# Altium schematic sheet Cryptography.SchDoc(Cryptography)
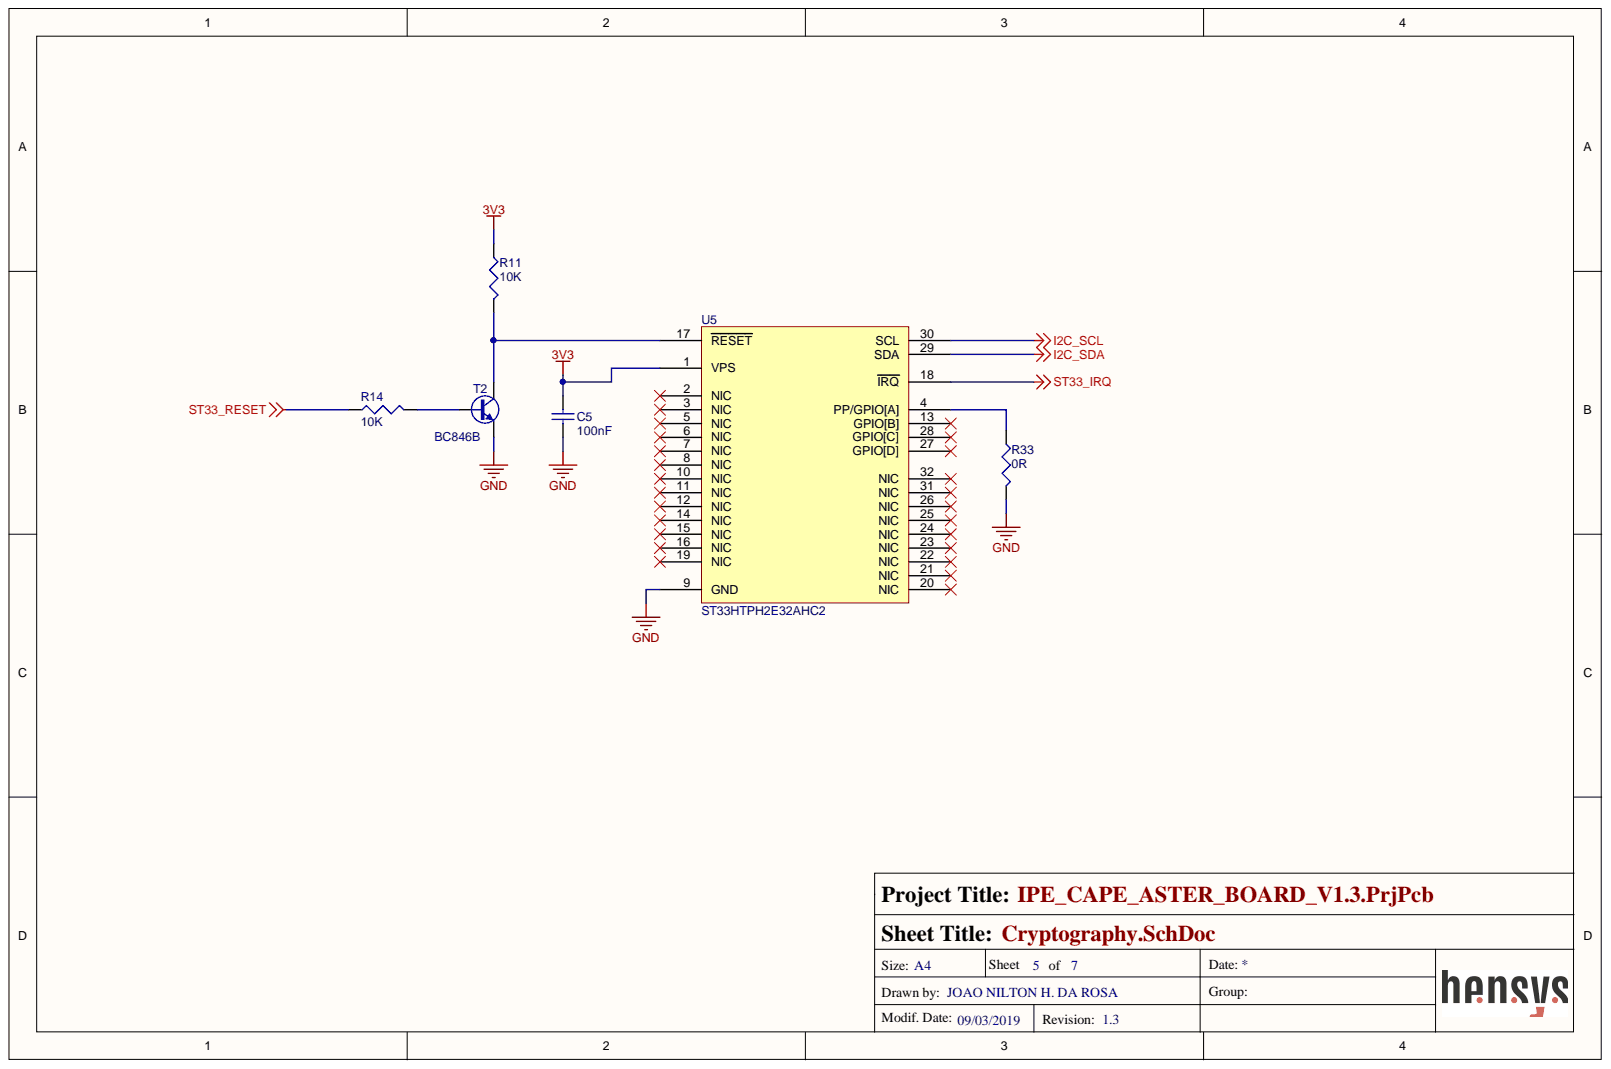
[diagram p0: Cryptography.SchDoc]

## Parts
C5  100nF  [0402 cap]
R11  10K  [0402 res]
R14  10K  [0402 res]
R33  0R  [0402 res]
T2  BC846B  [SOT95P230X110-3N]
U5  ST33HTPH2E32AHC2  [VFQFPN 32 5x5x1.0]

## Nets
3V3: C5.2 R11.1 U5.1(VPS)
GND: C5.1 R33.2 T2.2 U5.9(GND)
I2C_SCL: U5.30(SCL)
I2C_SDA: U5.29(SDA)
ST33_IRQ: U5.18(IRQ)
ST33_RESET: R14.1
NetR11_2: R11.2 T2.3 U5.17(RESET)
NetR14_2: R14.2 T2.1
NetR33_1: R33.1 U5.4(PP/GPIO[A])

Not connected: U5 2(NIC) 3(NIC) 5(NIC) 6(NIC) 7(NIC) 8(NIC) 10(NIC) 11(NIC) 12(NIC) 13(GPIO[B]) 14(NIC) 15(NIC) 16(NIC) 19(NIC) 20(NIC) 21(NIC) 22(NIC) 23(NIC) 24(NIC) 25(NIC) 26(NIC) 27(GPIO[D]) 28(GPIO[C]) 31(NIC) 32(NIC)

## Library data of the parts
C5 = Cap Ceram 0402: CAP CER 0.1UF 16V X7R 0402; Manufacturer Part Number: GCM155R71C104KA55D; Manufacturer: Murata Electronics North America; Tolerance: ±10%; Voltage - Rated: 16V; Thickness (Max): 0.022" (0.55mm); Temperature Coefficient: X7R; Supplier Part Number 1: 490-4759-1-ND; Supplier 1: Digi-Key; Stock 1: 918618; Size / Dimension: 0.039" L x 0.020" W (1.00mm x 0.50mm); RoHS: RoHS Compliant; Ratings: AEC-Q200; Pricing 1: 1=0.1, 10=0.039, 100=0.0174, 500=0.01244, 1000=0.00977, 2500=0.00888, 5000=0.00817 (USD); Part Status: Active; Packaging: Cut Tape (CT); Package / Case: 0402 (1005 Metric); Operating Temperature: -55°C ~ 125°C; Mounting Type: Surface Mount, MLCC; Lead Style: -; Lead Spacing: -; Height - Seated (Max): -; Features: -; ComponentLink1URL: http://psearch.en.murata.com/capacitor/product/GCM155R71C104KA55#.pdf; ComponentLink1Description: http://psearch.en.murata.com/capacitor/product/GCM155R71C104KA55#.pdf; Component Kind: Standard; Category: Capacitors; Applications: Automotive; Library Name: Passivos.IntLib
R11 R14 = Resistor 0402: RES SMD 10K OHM 5% 1/16W 0402; Manufacturer Part Number: RC1005J103CS; Manufacturer: Samsung Electro-Mechanics; Temperature Coefficient: ±100ppm/°C; Power (Watts): 0.063W, 1/16W; Tolerance: ±5%; Supplier Part Number 1: 1276-4400-1-ND; Supplier Device Package: 0402; Supplier 1: Digi-Key; Stock 1: 523260; Size / Dimension: 0.039" L x 0.020" W (1.00mm x 0.50mm); RoHS: RoHS Compliant; Pricing 1: 1=0.1, 10=0.011, 100=0.0046, 1000=0.00207, 2500=0.0018, 5000=0.00148 (USD); Part Status: Active; Packaging: Cut Tape (CT); Package / Case: 0402 (1005 Metric); Operating Temperature: -55°C ~ 155°C; Height - Seated (Max): 0.016" (0.40mm); Features: Moisture Resistant; Failure Rate: -; Composition: Thick Film; ComponentLink1URL: http://www.samsungsem.com/kr/support/product-search/chip-resistor/RC1005J103CS.jsp; ComponentLink1Description: http://www.samsungsem.com/kr/support/product-search/chip-resistor/RC1005J103CS.jsp; Component Kind: Standard; Category: Resistors; Library Name: Passivos.IntLib
R33 = Resistor 0402: RES SMD 0R OHM 1/16W 0402; ComponentLink1URL: http://www.samsungsem.com/kr/support/product-search/chip-resistor/RC1005J103CS.jsp; ComponentLink1Description: http://www.samsungsem.com/kr/support/product-search/chip-resistor/RC1005J103CS.jsp; Component Kind: Standard; Library Name: Passivos.IntLib
T2 = BC846B: NPN Transistor; Part Description: 65 V 100mA NPN general-purpose transistors; Manufacturer: NXP SEMICONDUCTORS; Table: Transistors; Socket: No; SMD: Yes; Pin Count: 3; Part Number: BC846B; PackageDescription: SOT23, 3 pin, Pitch 0.95mm - 1.3mm W X 2.9mm L X 1.1mm H, IPC Medium Density; Mounted: Yes; Manufacturer Part Number: BC846B; Library Name: ICs And Semiconductors.DbLib; LatestRevisionDate: 10/03/2011; HelpURL: \\cern.ch\dfs\Applications\Altium\Datasheets\BC846B.pdf; Device: BC846B; Database Table Name: Transistors; CreateDate: 10/03/2011; Component Type: Standard; Component Kind: Standard; ComponentHeight: 1.1mm; Case: SOT23-3; Author: CERN DEM JLC
U5 = ST33HTPH2E32AHC2: Trusted Platform Module VFQFPN 32; Component Kind: Standard; Library Name: STMicroelectronics Cryptography.IntLib
